# Altium schematic sheet ESP32_Control_Board_Design.SchDoc(ESP32_Control_Board_Design)
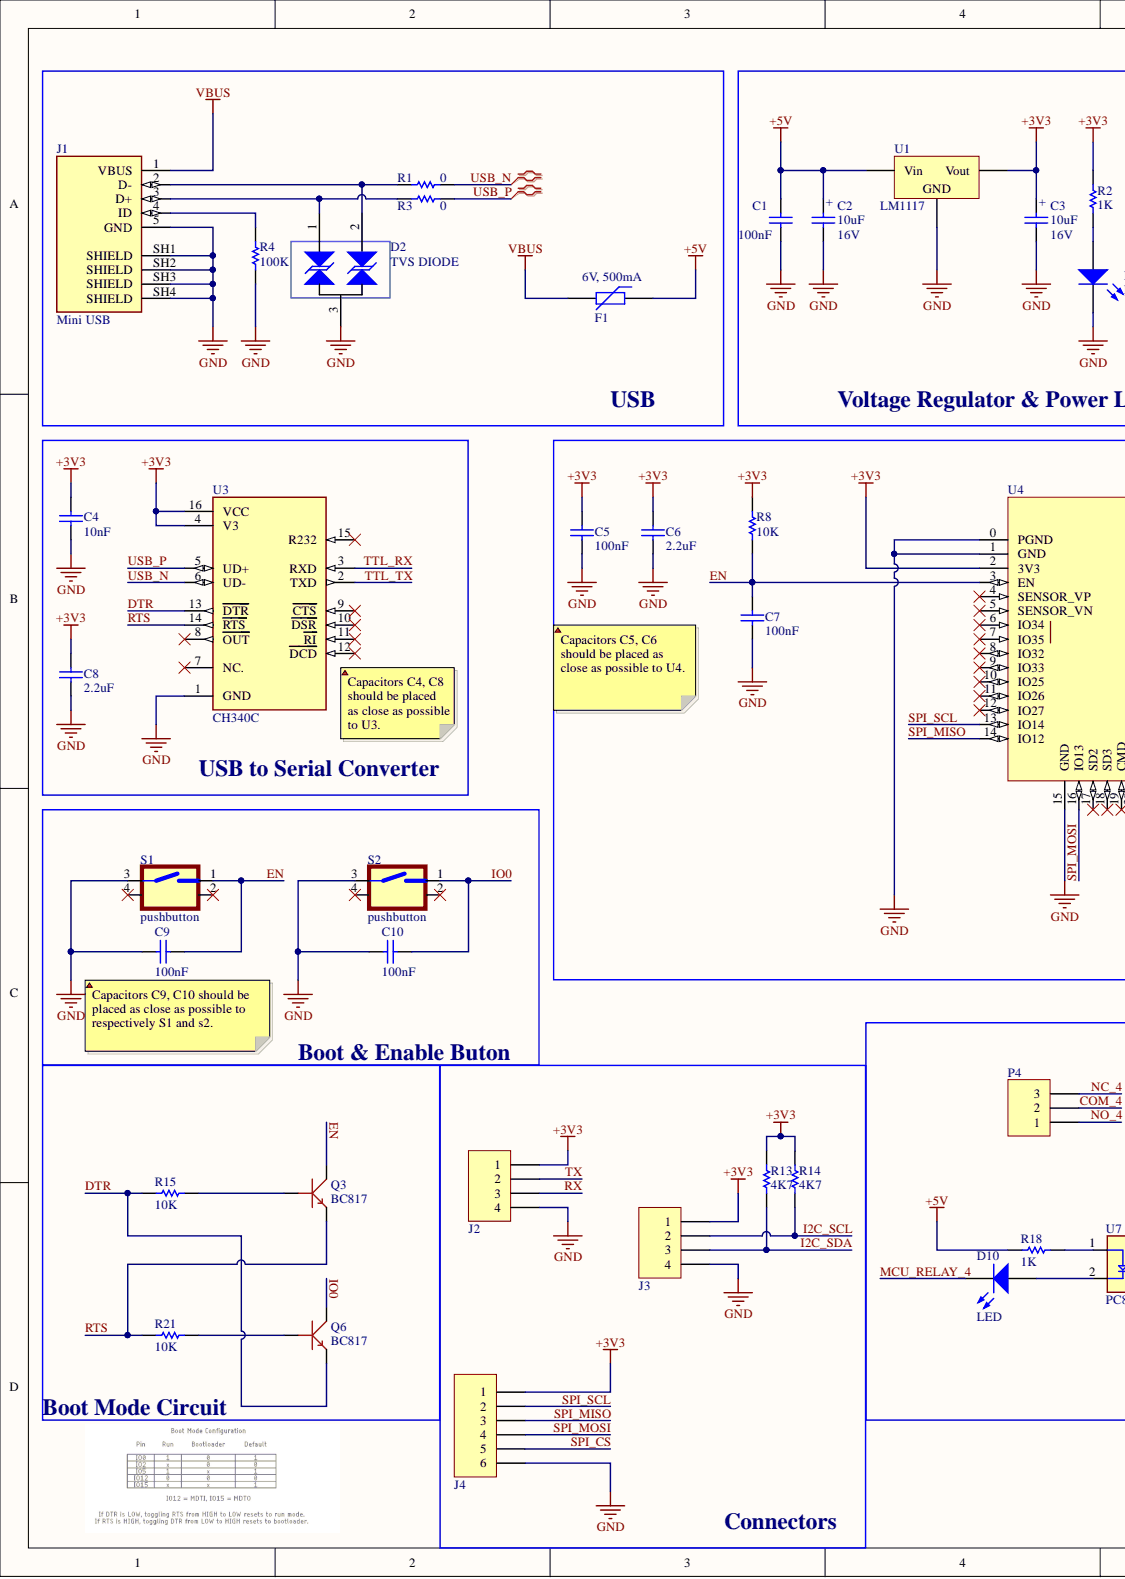
[diagram p0: ESP32_Control_Board_Design.SchDoc, left]
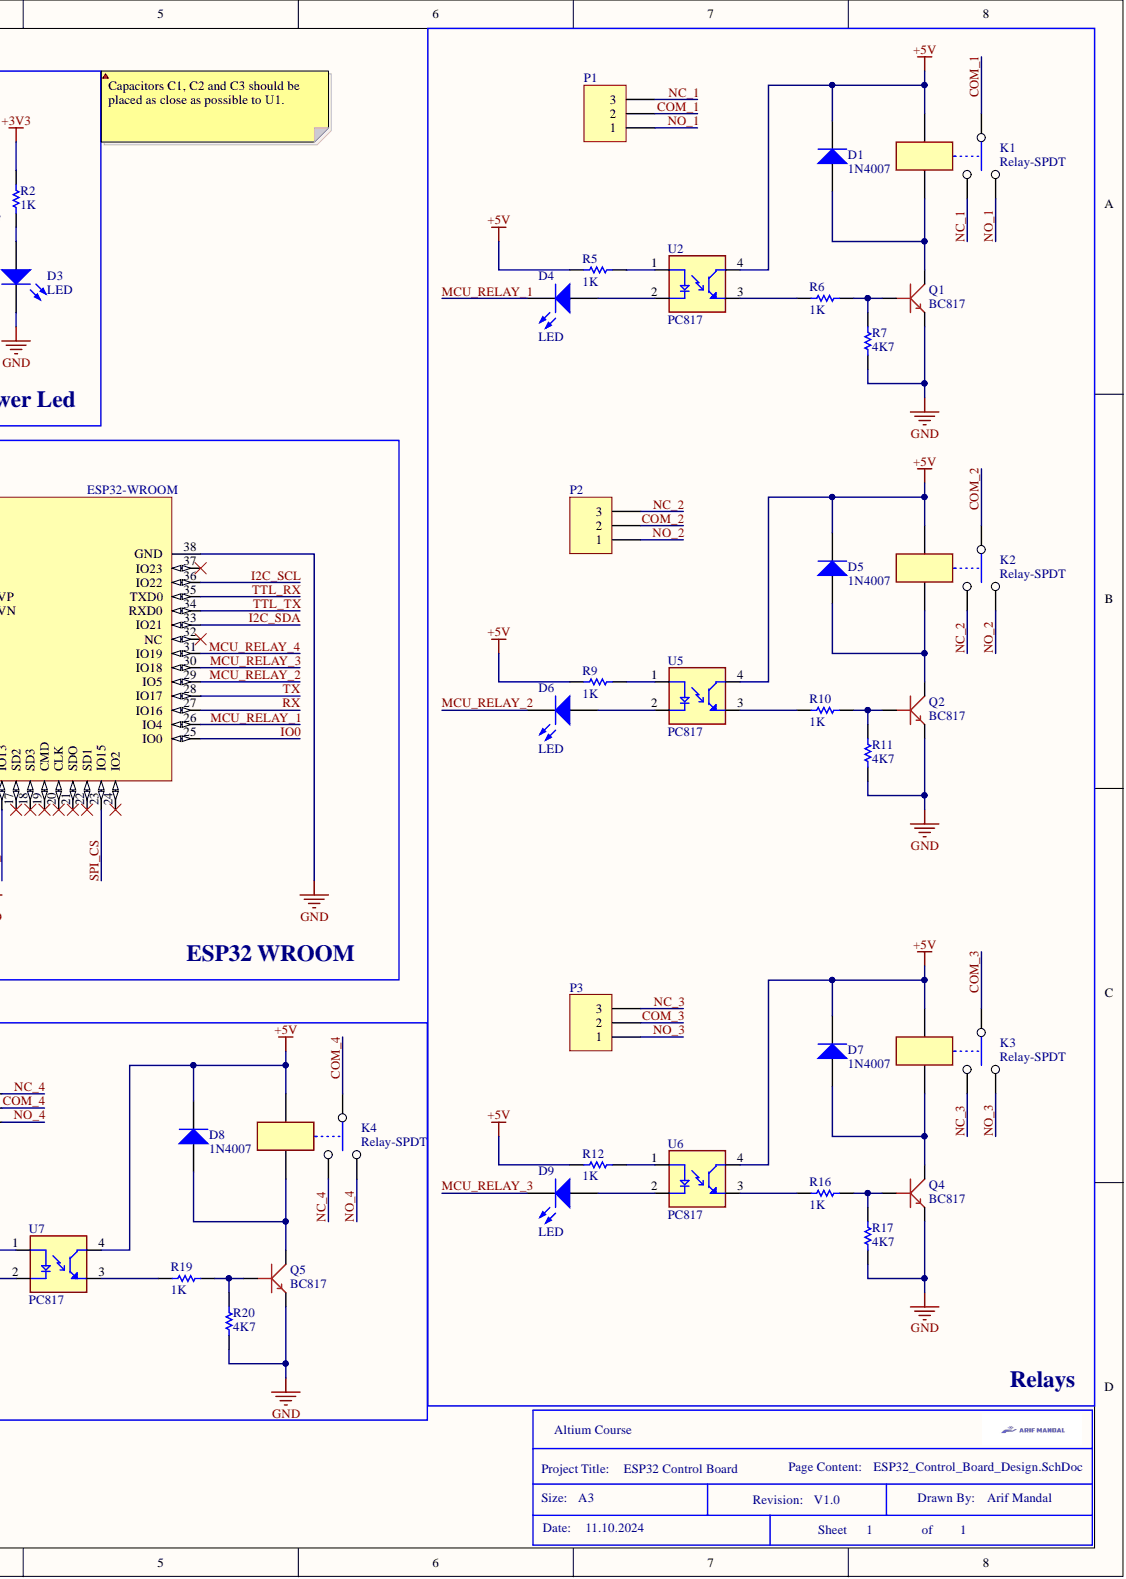
[diagram p1: ESP32_Control_Board_Design.SchDoc, right]

## Parts
C1  100nF  [C805]
C2  10uF  Volte: 16V  [MY_SMC_B]
C3  10uF  Volte: 16V  [MY_SMC_B]
C4  10nF  [C805]
C5  100nF  [C805]
C6  2.2uF  [C805]
C7  100nF  [C805]
C8  2.2uF  [C805]
C9  100nF  [C805]
C10  100nF  [C805]
D1  1N4007  [MY_1N4007_SMD]
D2  TVS DIODE  [SOT-23]
D3  LED  [MY_LED_1206]
D4  LED  [MY_LED_0805]
D5  1N4007  [MY_1N4007_SMD]
D6  LED  [MY_LED_0805]
D7  1N4007  [MY_1N4007_SMD]
D8  1N4007  [MY_1N4007_SMD]
D9  LED  [MY_LED_0805]
D10  LED  [MY_LED_0805]
F1  500mA  [PTC-0805]
J1  Mini USB  [MiniUSB]
J2  CONN-4  [XH-4]  (value not drawn)
J3  CONN-4  [XH-4]  (value not drawn)
J4  CONN-6  [XH-6]  (value not drawn)
K1  Relay-SPDT  [My_rel]
K2  Relay-SPDT  [My_rel]
K3  Relay-SPDT  [My_rel]
K4  Relay-SPDT  [My_rel]
P1  Header 3  [My_CN3]  (value not drawn)
P2  Header 3  [My_CN3]  (value not drawn)
P3  Header 3  [My_CN3]  (value not drawn)
P4  Header 3  [My_CN3]  (value not drawn)
Q1  BC817  [SOT23_3]
Q2  BC817  [SOT23_3]
Q3  BC817  [SOT23_3]
Q4  BC817  [SOT23_3]
Q5  BC817  [SOT23_3]
Q6  BC817  [SOT23_3]
R1  0  [MY_0805]
R2  1K  [MY_0805]
R3  0  [MY_0805]
R4  100K  [MY_0805]
R5  1K  [MY_0805]
R6  1K  [MY_0805]
R7  4K7  [MY_0805]
R8  10K  [MY_0805]
R9  1K  [MY_0805]
R10  1K  [MY_0805]
R11  4K7  [MY_0805]
R12  1K  [MY_0805]
R13  4K7  [MY_0805]
R14  4K7  [MY_0805]
R15  10K  [MY_0805]
R16  1K  [MY_0805]
R17  4K7  [MY_0805]
R18  1K  [MY_0805]
R19  1K  [MY_0805]
R20  4K7  [MY_0805]
R21  10K  [MY_0805]
S1  pushbutton  [button]
S2  pushbutton  [button]
U1  LM1117  [MY_SOT223]
U2  PC817  [SOIC-4N]
U3  CH340C  [SOIC-16N]
U4  ESP32-WROOM  [ESP32-WROOM32]
U5  PC817  [SOIC-4N]
U6  PC817  [SOIC-4N]
U7  PC817  [SOIC-4N]

## Nets
+3V3: C3.+ C4.2 C5.2 C6.2 C8.2 J2.1 J3.1 J4.1 R2.1 R8.1 R13.1 R14.1 U1.2 U3.4(V3) U3.16(VCC) U4.2(3V3)
+5V: C1.2 C2.+ D1.K D5.K D7.K D8.K F1.1 K1.4 K2.4 K3.4 K4.4 R5.1 R9.1 R12.1 R18.1 U1.3 U2.4 U5.4 U6.4 U7.4
GND: C1.1 C2.- C3.- C4.1 C5.1 C6.1 C7.1 C8.1 C9.2 C10.2 D2.3 D3.K J1.5(GND) J1.SH1(SHIELD) J1.SH2(SHIELD) J1.SH3(SHIELD) J1.SH4(SHIELD) J2.4 J3.4 J4.6 Q1.2 Q2.2 Q4.2 Q5.2 R4.2 R7.2 R11.2 R17.2 R20.2 S1.3 S2.3 U1.1 U3.1(GND) U4.0(PGND) U4.1(GND) U4.15(GND) U4.38(GND)
VBUS: F1.2 J1.1(VBUS)
COM_1: K1.1 P1.2
COM_2: K2.1 P2.2
COM_3: K3.1 P3.2
COM_4: K4.1 P4.2
DTR: Q6.2 R15.1 U3.13(DTR)
EN: C7.2 C9.1 Q3.3 R8.2 S1.1 U4.3(EN)
I2C_SCL: J3.2 R14.2 U4.36(IO22)
I2C_SDA: J3.3 R13.2 U4.33(IO21)
IO0: C10.1 Q6.3 S2.1 U4.25(IO0)
MCU_RELAY_1: D4.K U4.26(IO4)
MCU_RELAY_2: D6.K U4.29(IO5)
MCU_RELAY_3: D9.K U4.30(IO18)
MCU_RELAY_4: D10.K U4.31(IO19)
NC_1: K1.3 P1.3
NC_2: K2.3 P2.3
NC_3: K3.3 P3.3
NC_4: K4.3 P4.3
NO_1: K1.2 P1.1
NO_2: K2.2 P2.1
NO_3: K3.2 P3.1
NO_4: K4.2 P4.1
RTS: Q3.2 R21.1 U3.14(RTS)
RX: J2.3 U4.27(IO16)
SPI_CS: J4.5 U4.23(IO15)
SPI_MISO: J4.3 U4.14(IO12)
SPI_MOSI: J4.4 U4.16(IO13)
SPI_SCL: J4.2 U4.13(IO14)
TTL_RX: U3.3(RXD) U4.35(TXD0)
TTL_TX: U3.2(TXD) U4.34(RXD0)
TX: J2.2 U4.28(IO17)
USB_N: R1.2 U3.6(UD-)
USB_P: R3.2 U3.5(UD+)
NetD1_A: D1.A K1.5 Q1.3
NetD2_1: D2.1 J1.3(D+) R3.1
NetD2_2: D2.2 J1.2(D-) R1.1
NetD3_A: D3.A R2.2
NetD4_A: D4.A U2.2
NetD5_A: D5.A K2.5 Q2.3
NetD6_A: D6.A U5.2
NetD7_A: D7.A K3.5 Q4.3
NetD8_A: D8.A K4.5 Q5.3
NetD9_A: D9.A U6.2
NetD10_A: D10.A U7.2
NetJ1_4: J1.4(ID) R4.1
NetQ1_1: Q1.1 R6.2 R7.1
NetQ2_1: Q2.1 R10.2 R11.1
NetQ3_1: Q3.1 R15.2
NetQ4_1: Q4.1 R16.2 R17.1
NetQ5_1: Q5.1 R19.2 R20.1
NetQ6_1: Q6.1 R21.2
NetR5_2: R5.2 U2.1
NetR6_1: R6.1 U2.3
NetR9_2: R9.2 U5.1
NetR10_1: R10.1 U5.3
NetR12_2: R12.2 U6.1
NetR16_1: R16.1 U6.3
NetR18_2: R18.2 U7.1
NetR19_1: R19.1 U7.3

Not connected: S1 2 4; S2 2 4; U3 7(NC.) 8(OUT) 9(CTS) 10(DSR) 11(RI) 12(DCD) 15(R232); U4 4(SENSOR_VP) 5(SENSOR_VN) 6(IO34) 7(IO35) 8(IO32) 9(IO33) 10(IO25) 11(IO26) 12(IO27) 17(SD2) 18(SD3) 19(CMD) 20(CLK) 21(SDO) 22(SD1) 24(IO2) 32(NC) 37(IO23)

## Library data of the parts
C1 C4-C10 = Cap: Capacitor; Designer: Parsa Malekpour; Library Name: ParsaLibrary.SchLib; Component Kind: Standard
  C1 C5 C7 C9 C10: Value: 100nF
  C4: Value: 10nF
  C6 C8: Value: 2.2uF
C2 C3 = Cap Pol: Polar capacitor; ASiD Part Number: C-AS; Value: 10uF; Library Name: Mylib.IntLib; Component Kind: Standard
D1 D5 D7 D8 = 1N4007: 1 Amp Diode; ASiD Part Number: 1n4007-AS; Library Name: Mylib.IntLib; Component Kind: Standard
D2 = SM712: Library Name: STLink_V2_Design.IntLib; Component Kind: Standard
D3 D4 D6 D9 D10 = LED: Typical INFRARED GaAs LED; ASiD Part Number: LED-AS; Library Name: Mylib.IntLib; Component Kind: Standard
F1 = PTC: Published: 24-Mar-1999; PackageVersion: Mar-2003; PackageReference: 6-0805; Description: PTC; Component Kind: Standard; Library Name: ParsaLibrary.SchLib
J1 = Mini USB: MINI USB 2.0- B Type Receptacle conn. Right Angle Surface Mount Type; MP: 10033526-N3212LF; MF: Amphenol ICC; SnapEDA_Link: https://www.snapeda.com/parts/10033526-N3212LF/Amphenol+FCI/view-part/?ref=snap; Package: None; Library Name: ESP32_Control_Board_Design.SchLib; Component Kind: Standard; Check_prices: https://www.snapeda.com/parts/10033526-N3212LF/Amphenol+FCI/view-part/?ref=eda
K1-K4 = Relay-SPDT: Standard single contact relay; ASiD Part Number: Relay-SPDT-AS; Library Name: Mylib.IntLib; Component Kind: Standard
P1-P4 = Header 3: Header, 3-Pin; ASiD Part Number: Header 3-AS; Signal Integrity: Connector; Library Name: Mylib.IntLib; Component Kind: Standard
Q1-Q6 = bc817-16: Library Name: Led_Switching.IntLib; Component Kind: Standard
R1-R21 = Res: Standard resistor; ASiD Part Number: Res-AS; Library Name: Mylib.IntLib; Component Kind: Standard
  R1 R3: Value: 0
  R2 R5 R6 R9 R10 R12 R16 R18 R19: Value: 1K
  R4: Value: 100K
  R7 R11 R13 R14 R17 R20: Value: 4K7
  R8 R15 R21: Value: 10K
U1 = LM1117: Low Drop Voltage Reg; ASiD Part Number: LM1117-AS; Library Name: Mylib.IntLib; Component Kind: Standard
U2 U5-U7 = PC817: Optoisolator Transistor Output 1 Channel 4-SMD; MP: PC817X1NIP1B; MF: Sharp Microelectronics; SnapEDA_Link: https://www.snapeda.com/parts/PC817X1NIP1B/Sharp+Microelectronics/view-part/?ref=snap; Package: None; Library Name: ESP32_Control_Board_Design.SchLib; Component Kind: Standard; Check_prices: https://www.snapeda.com/parts/PC817X1NIP1B/Sharp+Microelectronics/view-part/?ref=eda
U3 = CH340C: Replacement for Brother P-Touch; MF: Olimex; SnapEDA_Link: https://www.snapeda.com/parts/CH340C/Olimex/view-part/?ref=snap; Package: None; MP: CH340C; Library Name: ESP32_Control_Board_Design.SchLib; Component Kind: Standard; Check_prices: https://www.snapeda.com/parts/CH340C/Olimex/view-part/?ref=eda
U4 = ESP32-WROOM32: Component Kind: Standard; Library Name: ParsaLibrary.SchLib
J2 J3 = CONN-4: Component Kind: Standard; Library Name: ParsaLibrary.SchLib
J4 = CONN-6: Component Kind: Standard; Library Name: ParsaLibrary.SchLib
S1 S2 = pushbutton: Library Name: Led_Switching.IntLib; Component Kind: Standard
